# Altium schematic sheet Alimentation.SchDoc(Alimentation)
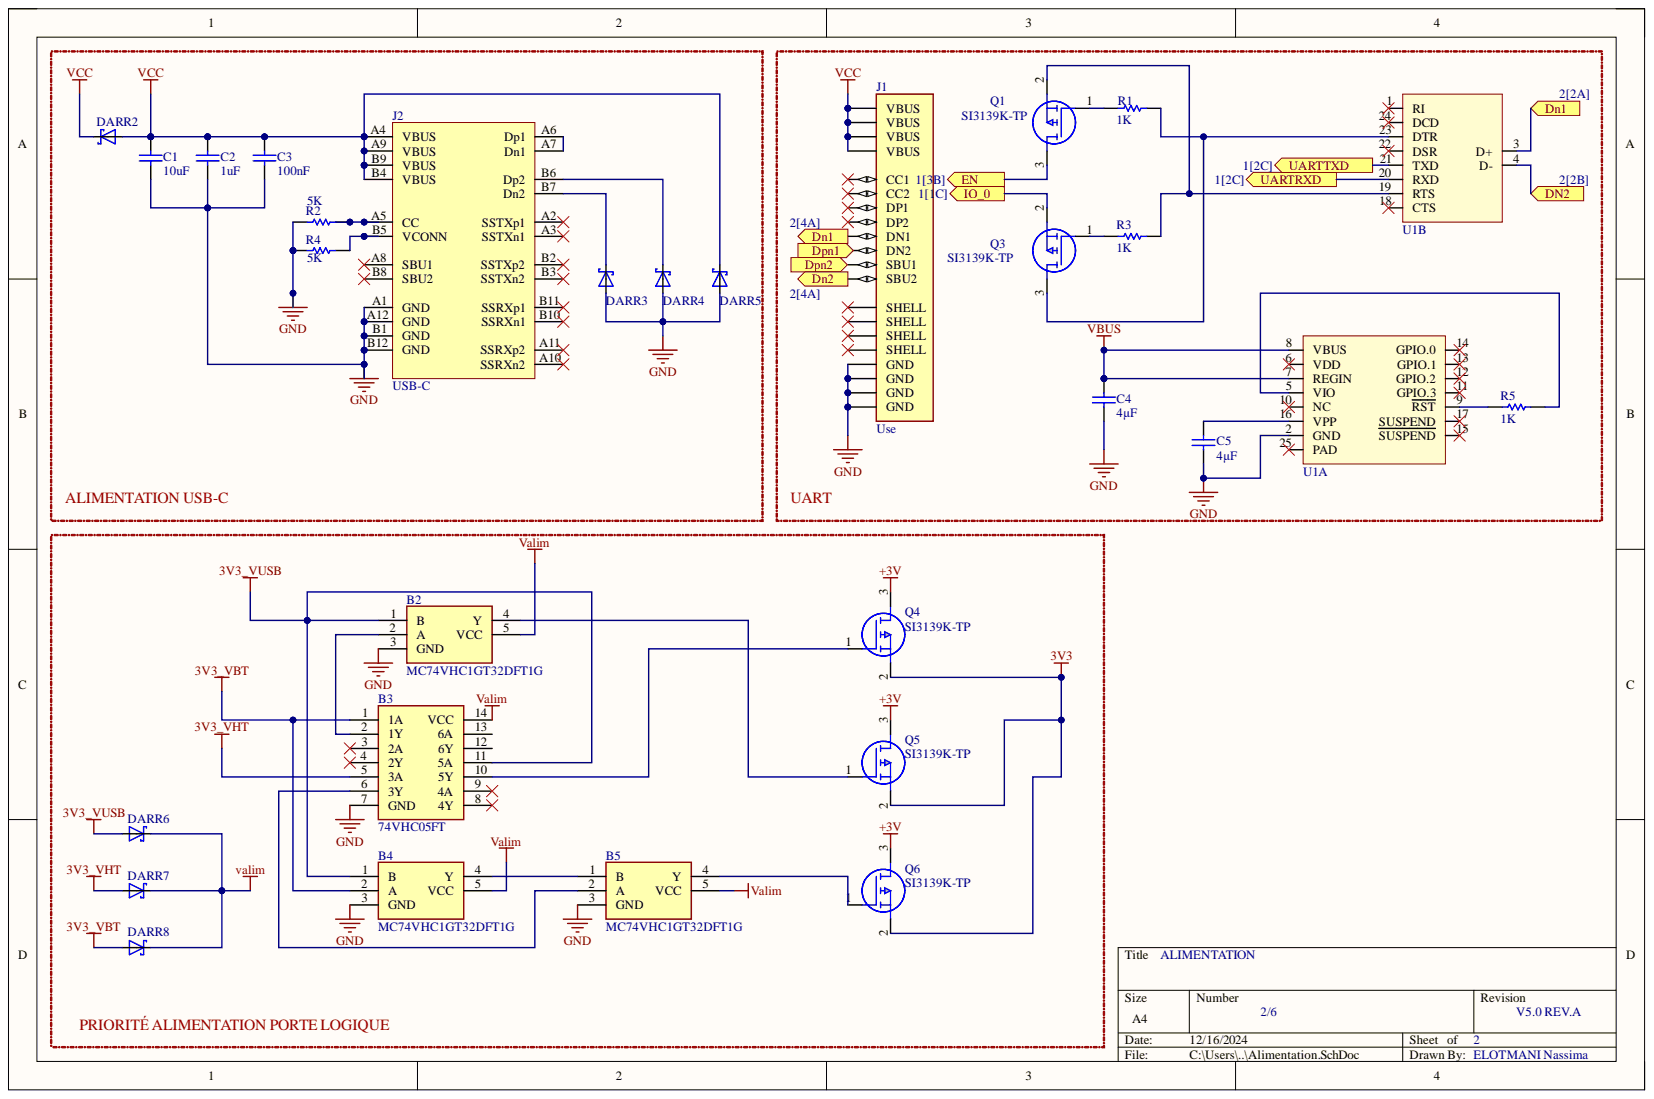
[diagram p0: Alimentation.SchDoc]

## Parts
B2  MC74VHC1GT32DFT1G  [SOT65P210X110-5N]
B3  74VHC05FT  [SOP65P640X120-14N]
B4  MC74VHC1GT32DFT1G  [SOT65P210X110-5N]
B5  MC74VHC1GT32DFT1G  [SOT65P210X110-5N]
C1  value 10uF  (hidden comment: Capacitor)
C2  value 1uF  (hidden comment: Capacitor)
C3  value 100nF  (hidden comment: Capacitor)
C4  value 4µF  (hidden comment: Capacitor)
C5  value 4µF  (hidden comment: Capacitor)
DARR2  SD103AWS-7-F  [SD103AWS-7-F]  (value not drawn)
DARR3  SD103WS-7-F  [SD103AWS-7-F]  (value not drawn)
DARR4  SD103AWS-7-F  [SD103AWS-7-F]  (value not drawn)
DARR5  SD103AWS-7-F  [SD103AWS-7-F]  (value not drawn)
DARR6  SD103AWS-7-F  [SD103AWS-7-F]  (value not drawn)
DARR7  SD103AWS-7-F  [SD103AWS-7-F]  (value not drawn)
DARR8  SD103AWS-7-F  [SD103AWS-7-F]  (value not drawn)
J1  Use  [USB4085-GF-A]
J2  USB-C  [USB-C]
Q1  SI3139K-TP  [SI3139K-TP]
Q3  SI3139K-TP  [SI3139K-TP]
Q4  SI3139K-TP  [SI3139K-TP]
Q5  SI3139K-TP  [SI3139K-TP]
Q6  SI3139K-TP  [SI3139K-TP]
R1  value 1K  (hidden comment: Resistor)
R2  value 5K  (hidden comment: Resistor)
R3  value 1K  (hidden comment: Resistor)
R4  value 5K  (hidden comment: Resistor)
R5  value 1K  (hidden comment: Resistor)
U1  CP2104-F03-GM  [PQFN50P400X400X80_HS-25N]  (value not drawn)  (parts A,B)

## Ports
Dn1, DN2, Dn2, Dpn1, Dpn2, EN, IO_0, UARTRXD, UARTTXD

## Nets
3V3: Q4.2 Q4.3 Q5.2 Q5.3 Q6.2 Q6.3
3V3_VBT: B3.1(1A) B4.2(A) DARR8.2
3V3_VHT: B3.5(3A) DARR7.2
3V3_VUSB: B2.1(B) B3.11(5A) B4.1(B) DARR6.2
GND: B2.3(GND) B3.7(GND) B4.3(GND) B5.3(GND) C1.2 C2.2 C3.2 C4.1 C5.1 DARR3.2 DARR4.2 DARR5.2 J1.A1(GND) J1.A12(GND) J1.B1(GND) J1.B12(GND) J2.A1(GND) J2.A12(GND) J2.B1(GND) J2.B12(GND) R2.1 R4.1 U1.2(GND)
valim: B2.5(VCC) B3.14(VCC) B4.5(VCC) B5.5(VCC) DARR6.1 DARR7.1 DARR8.1
VBUS: C4.2 U1.7(REGIN) U1.8(VBUS)
VCC: C1.1 C2.1 C3.1 DARR2.1 DARR2.2 DARR5.1 J1.A4(VBUS) J1.A9(VBUS) J1.B4(VBUS) J1.B9(VBUS) J2.A4(VBUS) J2.A9(VBUS) J2.B4(VBUS) J2.B9(VBUS)
Dn1: J1.A7(DN1) U1.3(D+) ; port Dn1
DN2: J1.B8(SBU2) U1.4(D-) ; port DN2, port Dn2
EN: Q1.3 ; port EN
IO_0: Q3.2 ; port IO_0
UARTRXD: U1.20(RXD) ; port UARTRXD
UARTTXD: U1.21(TXD) ; port UARTTXD
NetB2_2: B2.2(A) B3.2(1Y)
NetB2_4: B2.4(Y) Q5.1
NetB3_6: B3.6(3Y) B5.2(A)
NetB3_10: B3.10(5Y) Q4.1
NetB3_12: B3.12(6Y)
NetB4_4: B4.4(Y) B5.1(B)
NetB5_4: B5.4(Y) Q6.1
NetC5_2: C5.2 U1.16(VPP)
NetDARR3_1: DARR3.1 J2.B7(Dn2)
NetDARR4_1: DARR4.1 J2.B6(Dp2)
NetJ2_A5: J2.A5(CC) R2.2
NetJ2_A6: J2.A6(Dp1) J2.A7(Dn1)
NetJ2_B5: J2.B5(VCONN) R4.2
NetQ1_1: Q1.1 R1.2
NetQ1_2: Q1.2 R3.1 U1.19(RTS)
NetQ3_1: Q3.1 R3.2
NetQ3_3: Q3.3 R1.1 U1.23(DTR)
NetR5_1: R5.1 U1.5(VIO)
NetR5_2: R5.2 U1.9(RST)
N00002: ; port Dpn2
N00003: ; port Dpn1

Not connected: B3 3(2A) 4(2Y) 8(4Y) 9(4A) 13(6A); J1 A5(CC1) A6(DP1) A8(SBU1) B5(CC2) B6(DP2) B7(DN2) S1(SHELL) S2(SHELL) S3(SHELL) S4(SHELL); J2 A2(SSTXp1) A3(SSTXn1) A8(SBU1) A10(SSRXn2) A11(SSRXp2) B2(SSTXp2) B3(SSTXn2) B8(SBU2) B10(SSRXn1) B11(SSRXp1); U1 1(RI) 6(VDD) 10(NC) 11(GPIO.3) 12(GPIO.2) 13(GPIO.1) 14(GPIO.0) 15(SUSPEND) 17(SUSPEND) 18(CTS) 22(DSR) 24(DCD) 25(PAD)

## Library data of the parts
B2 B4 B5 = MC74VHC1GT32DFT1G: Single 2-Input OR Gate, TTL Level; Manufacturer_Name: onsemi; Component Kind: Standard; Library Name: SCHLibrary.SchLib; Manufacturer_Part_Number: MC74VHC1GT32DFT1G; Mouser Part Number: 863-C74VHC1GT32DFT1G
B3 = 74VHC05FT: Logic Gates CMOS LOGIC IC INVERTER; Manufacturer_Name: Toshiba; Component Kind: Standard; Library Name: SCHLibrary.SchLib; Manufacturer_Part_Number: 74VHC05FT; Mouser Part Number: 757-74VHC05FT
C1-C5 = Capacitor: SimulationGenericComponent: True; Component Kind: Standard; Description: Capacitor; Library Name: Simulation Generic Components.IntLib; Simulation: C_Capacitor_Default
DARR2-DARR8 = SD103AWS-7-F: Diode Array 3 Independent Schottky 40V 175mA Surface Mount 6-TSSOP, SC-88, SOT-363; Component Kind: Standard; Library Name: SCHLibrary.SchLib
J1 = USB4085-GF-A: Connecteurs USB USB Type C, 2.0, Rec, TH , G/F, RA , Top Mnt, T & R; Component Kind: Standard; Library Name: SCHLibrary.SchLib
J2 = USB-C: NexarPartId: 68112675; Connector Type: USB; Contact Resistance: 30mΩ; Current Rating: 5A; Gender: Receptacle; Insulation Resistance: 1GΩ; Number of Contacts: 24; Pin Length: 1.9mm; USB Standard: USB 3.1; Voltage Rating: 20V; Component Kind: Standard; Contact Material: Gold, Nickel; Height: 3.21mm; Library Name: Schematic_lib.SchLib; Max Operating Temperature: 105°C; Min Operating Temperature: -40°C; Mount: Surface Mount, Through Hole; Number of Pins: 24; Orientation: Horizontal; Packaging: Tape and Reel; RoHS: Compliant
Q1 Q3-Q6 = SI3139K-TP: MOSFET P-Channel MOSFET; Component Kind: Standard; Library Name: SCHLibrary.SchLib
R1-R5 = Resistor: SimulationGenericComponent: True; TC1: 0; Component Kind: Standard; Description: Resistor; Library Name: Simulation Generic Components.IntLib; Simulation: R_Resistor_Default; TC2: 0
U1 = USBBridge: USB Bridge, USB to UART USB 2.0 UART Interface 24-QFN (4x4); Manufacturer 1: Silicon Labs; Component Kind: Standard; Library Name: SCHLibrary.SchLib; Manufacturer Part Number 1: CP2104-F03-GM; Supplier 1: Arrow Electronics; Supplier Part Number 1: CP2104-F03-GM
